# Altium schematic sheet [6]-OPI_POWER.SchDoc([6]-OPI_POWER)
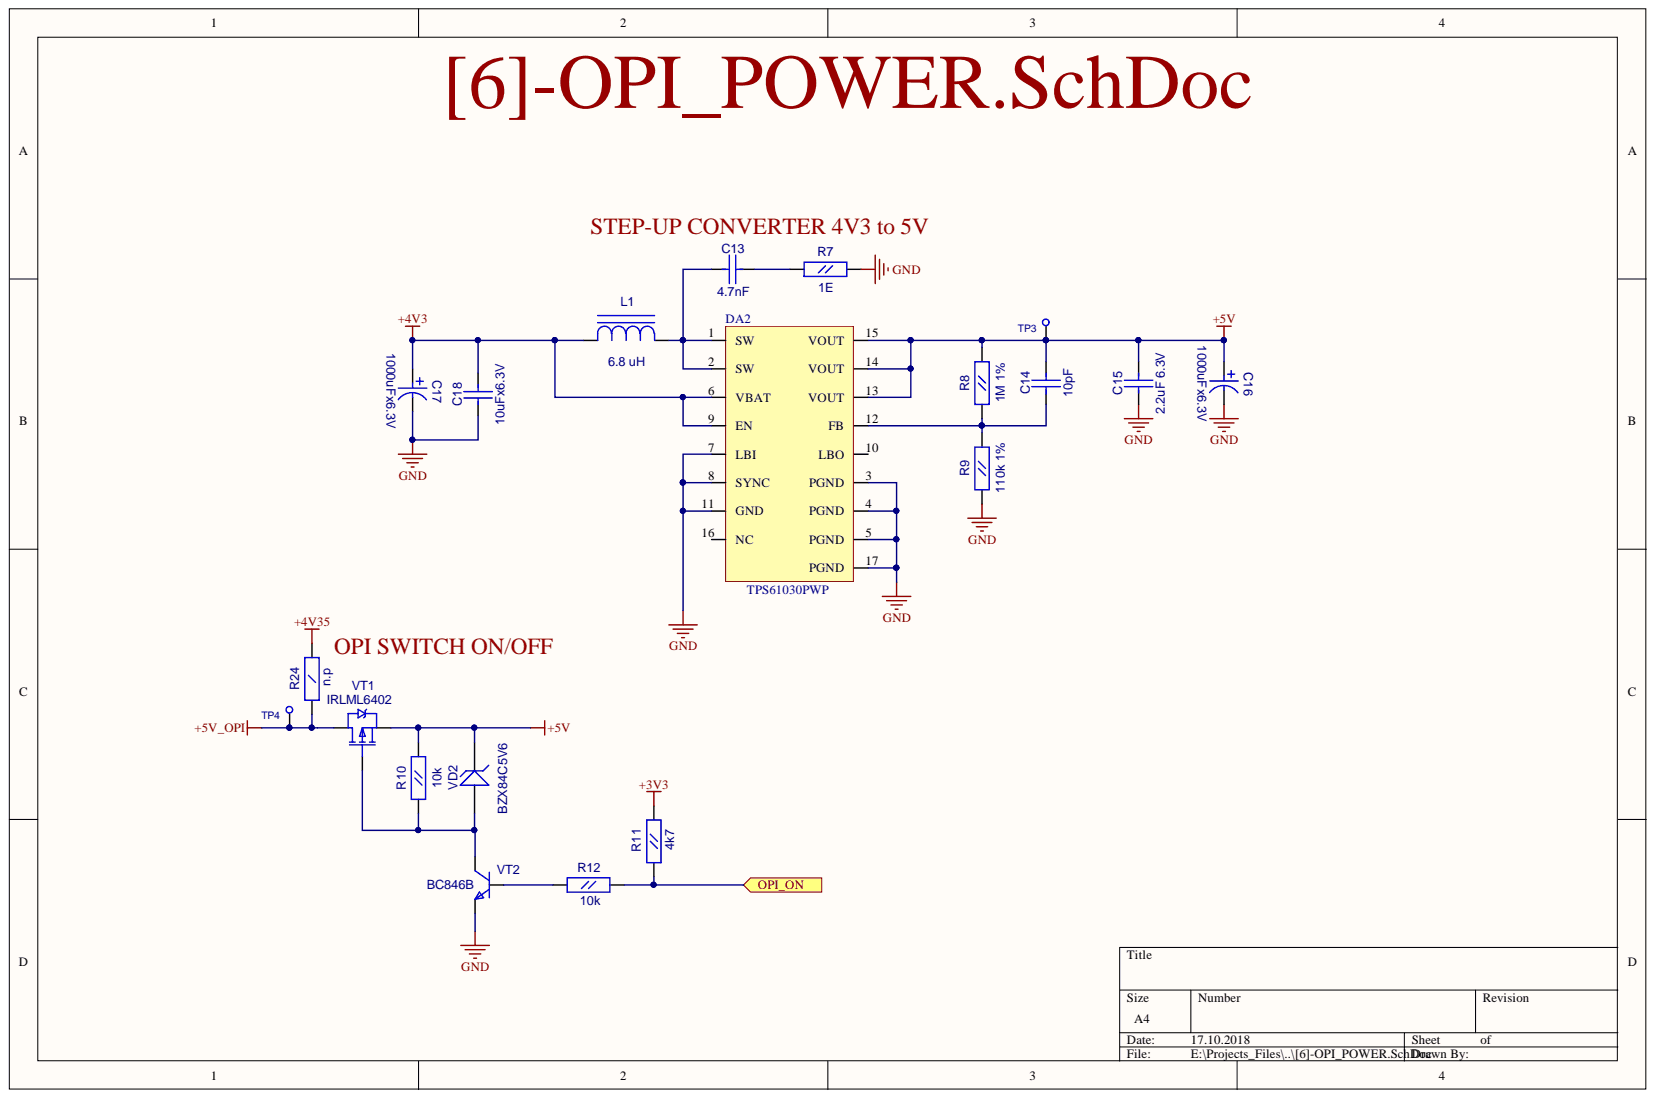
[diagram p0: [6]-OPI_POWER.SchDoc]

## Parts
C13  4.7nF  [CC0603]
C14  10pF  [CC0603]
C15  2.2uF 6.3V  [CC0805]
C16  value 1000uFx6.3V  [CC8X3.5]  (hidden comment: CAP0.35)
C17  value 1000uFx6.3V  [CC8X3.5]  (hidden comment: CAP0.35)
C18  10uFx6.3V  [CC0805]
DA2  TPS61030PWP  [R-PDSO-G16]
L1  6.8 uH  [SDR0805]
R7  1E  [RC0603]
R8  1M 1%  [RC0603]
R9  110k 1%  [RC0603]
R10  10k  [RC0603]
R11  4k7  [RC0603]
R12  10k  [RC0603]
R24  n.p  [RC1206]
TP3  TESTPOINT1  [TESTPOINT1]  (value not drawn)
TP4  TESTPOINT1  [TESTPOINT1]  (value not drawn)
VD2  BZX84C5V6  [SOT-23(123)]
VT1  IRLML6402  [SOT-23(123)]
VT2  BC846B  [SOT-23(123)]

## Ports
OPI_ON

## Nets
+3V3: R11.2
+4V3: C17.1 C18.2 DA2.6(VBAT) DA2.9(EN) L1.1
+4V35: R24.2
+5V: C14.2 C15.2 C16.1 DA2.13(VOUT) DA2.14(VOUT) DA2.15(VOUT) R8.2 R10.2 TP3.1 VD2.3 VT1.2
+5V_OPI: R24.1 TP4.1 VT1.3
GND: C15.1 C16.2 C17.2 C18.1 DA2.3(PGND) DA2.4(PGND) DA2.5(PGND) DA2.7(LBI) DA2.8(SYNC) DA2.11(GND) DA2.17(PGND) R7.2 R9.1 VT2.2
OPI_ON: R11.1 R12.2 ; port OPI_ON
NetC13_1: C13.1 DA2.1(SW) DA2.2(SW) L1.2
NetC13_2: C13.2 R7.1
NetC14_1: C14.1 DA2.12(FB) R8.1 R9.2
NetR10_1: R10.1 VD2.1 VT1.1 VT2.3
NetR12_1: R12.1 VT2.1

Not connected: DA2 10(LBO) 16(NC)

## Library data of the parts
C13 C14 = CC0603: Description: CC0603; Component Kind: Standard; Library Name: gprs_modem_2.SchLib
  C13: Value: 4.7nF
  C14: Value: 10pF
C15 C18 = CC0805: Description: CC0805; Component Kind: Standard; Library Name: gprs_modem_2.SchLib
  C15: Value: 2.2uF 6.3V
  C18: Value: 10uFx6.3V
DA2 = TPS61030PWP: Boost converter; Component Kind: Standard; Library Name: gprs_modem.SchLib
L1 = SDR0805: Value: 6.8 uH; Description: SDR0805; Component Kind: Standard; Library Name: gprs_modem_2.SchLib
R7-R12 = RC0603: Description: RC0603; Component Kind: Standard
  R7: Value: 1E
  R8: Value: 1M 1%
  R9: Value: 110k 1%
  R10 R12: Value: 10k
  R11: Value: 4k7
  R7-R10: Library Name: gprs_modem_2.SchLib
  R11 R12: Library Name: fizik_lib.SchLib
R24 = RC1206: Value: n.p; Description: RC1206; Component Kind: Standard; Library Name: fizik_lib.SchLib
VD2 = BZX84: Zener Voltage Regulator Diode; Value: BZX84C5V6; Component Kind: Standard; Library Name: gprs_modem_2.SchLib
VT1 = IRLML6402: HEXFET Power MOSFET; OriginalName: IRLML5103; ComponentField7: Published 8-Jun-2000; Value: IRLML6402; Component Kind: Standard; Library Name: gprs_modem_2.SchLib
VT2 = BC846BLT1: ComponentField7: Published 29-Sep-2000; Value: BC846B; Simulation: BC846BLT1; OriginalName: BC846BLT1; Description: BC846BLT1; Component Kind: Standard; Library Name: gprs_modem_2.SchLib
C16 C17 = CAP0.35: Description: CAP0.35; Component Kind: Standard; Library Name: gprs_modem_2.SchLib
TP3 TP4 = TESTPOINT1: Description: TESTPOINT1; Component Kind: Standard; Library Name: fizik_lib.SchLib
